# Altium schematic sheet steam-kitv1.SchDoc(steam-kitv1)
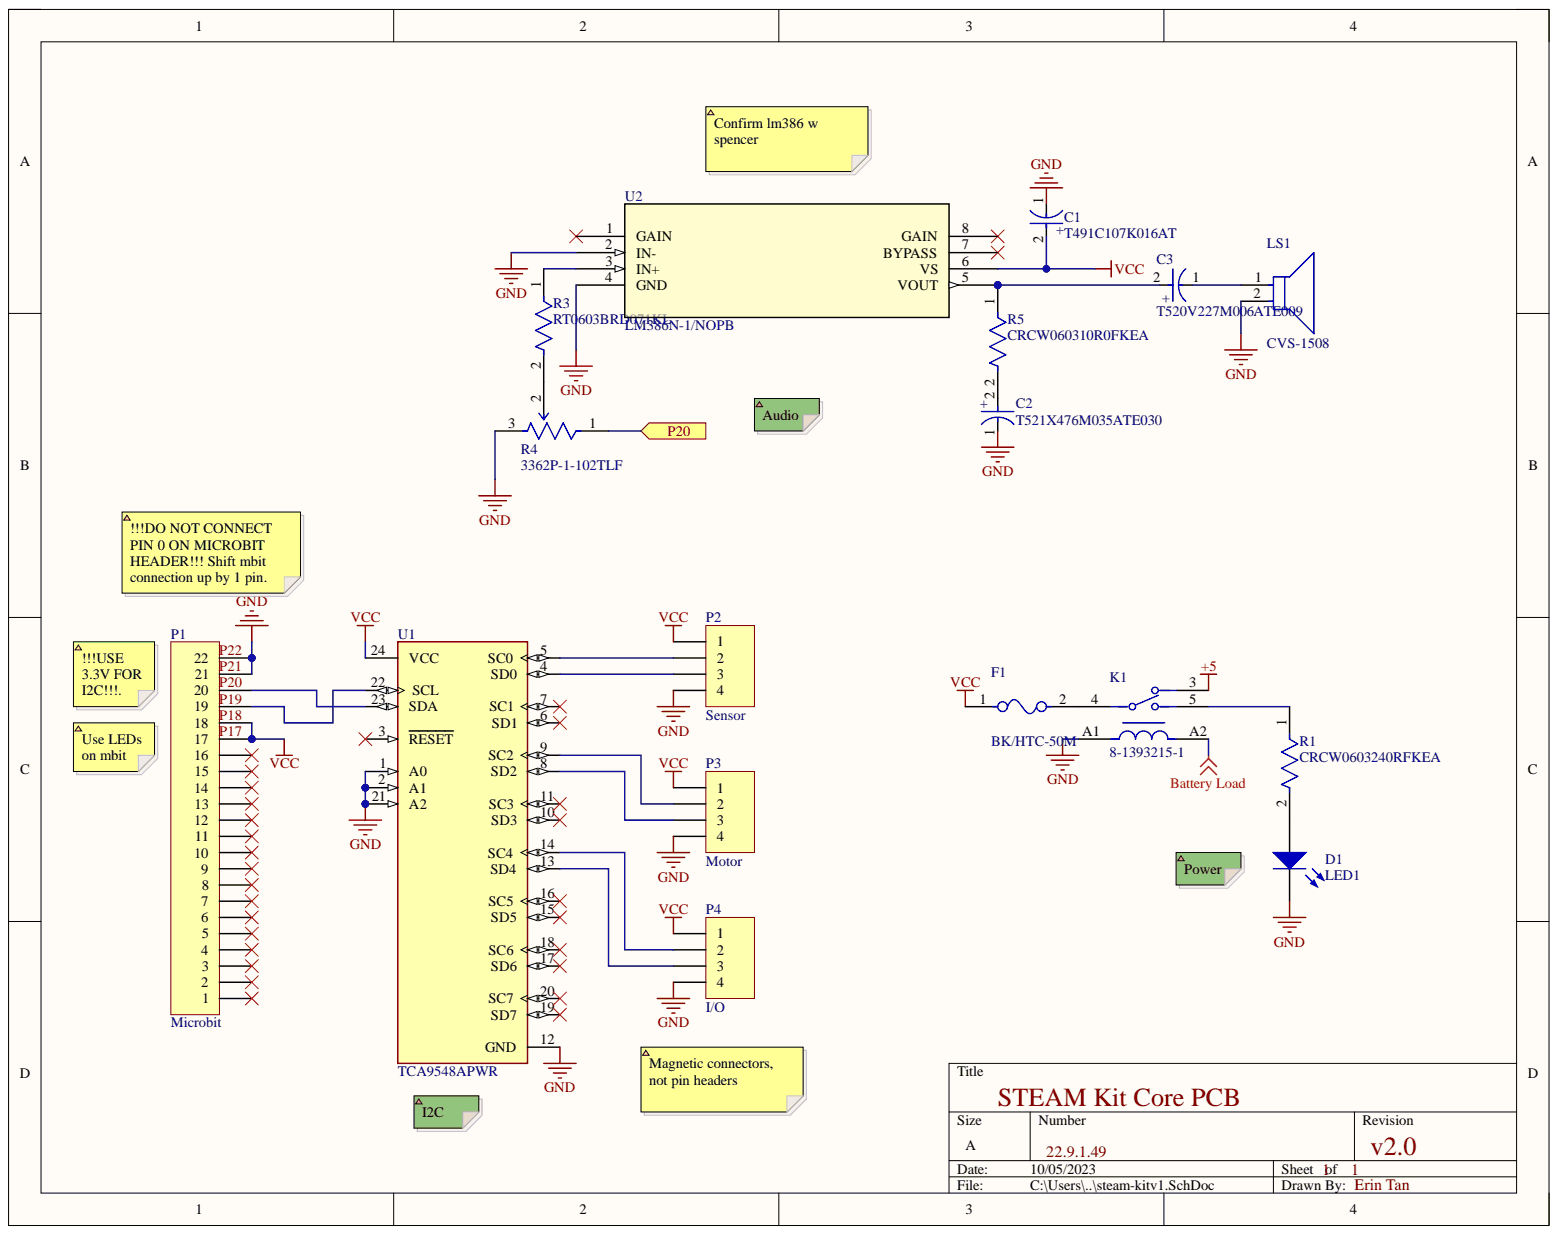
[diagram p0: steam-kitv1.SchDoc]

## Parts
C1  T491C107K016AT  [FP-T491C107K016AT-MFG]
C2  T521X476M035ATE030  [FP-T521X476M035ATE030-MFG]
C3  T520V227M006ATE009  [FP-T520V227M006ATE009-MFG]
D1  LED1  [LED-1]
F1  BK/HTC-50M  [FP-BK-HTC-50M-MFG]
K1  8-1393215-1  [FP-8-1393215-1-MFG]
LS1  CVS-1508  [FP-CVS-1508-MFG]
P1  Microbit  [HDR1X22]
P2  Sensor  [HDR1X4]
P3  Motor  [HDR1X4]
P4  I/O  [HDR1X4]
R1  CRCW0603240RFKEA  [FP-CRCW0603-e3-IPC_B]
R3  RT0603BRD071KL  [FP-RT0603-MFG]
R4  3362P-1-102TLF  [FP-3362P-1-102TLF-MFG]
R5  CRCW060310R0FKEA  [FP-CRCW0603-e3-IPC_A]
U1  TCA9548APWR  [FP-PW0024A-MFG]
U2  LM386N-1/NOPB  [N08E_TEX]

## Ports
P20

## Nets
+5: K1.3
Battery Load: K1.A2
GND: C1.1 C2.1 D1.2 K1.A1 LS1.2 P1.21 P1.22 P2.4 P3.4 P4.4 R4.3 U1.1(A0) U1.2(A1) U1.12(GND) U1.21(A2) U2.2(IN-) U2.4(GND)
VCC: C1.2 F1.1 P1.17 P1.18 P2.1 P3.1 P4.1 U1.24(VCC) U2.6(VS)
P20: R4.1 ; port P20
NetC2_2: C2.2 R5.2
NetC3_1: C3.1 LS1.1
NetC3_2: C3.2 R5.1 U2.5(VOUT)
NetD1_1: D1.1 R1.2
NetF1_2: F1.2 K1.4
NetK1_5: K1.5 R1.1
NetP1_19: P1.19 U1.22(SCL)
NetP1_20: P1.20 U1.23(SDA)
NetP2_2: P2.2 U1.5(SC0)
NetP2_3: P2.3 U1.4(SD0)
NetP3_2: P3.2 U1.9(SC2)
NetP3_3: P3.3 U1.8(SD2)
NetP4_2: P4.2 U1.14(SC4)
NetP4_3: P4.3 U1.13(SD4)
NetR3_1: R3.1 U2.3(IN+)
NetR3_2: R3.2 R4.2
P17:
P18:
P19:
P20:
P21:
P22:

Not connected: P1 1 2 3 4 5 6 7 8 9 10 11 12 13 14 15 16; U1 3(RESET) 6(SD1) 7(SC1) 10(SD3) 11(SC3) 15(SD5) 16(SC5) 17(SD6) 18(SC6) 19(SD7) 20(SC7); U2 1(GAIN) 7(BYPASS) 8(GAIN)

## Library data of the parts
C1 = CMP-03017-000015-1: CAP TANT 100UF 10% 16V 2312; Dielectric Material: Tantalum; ESR (Equivalent Series Resistance): 1R; Leakage Current: 16uA; Life (Hours): 2000Hour; Polarity: Polar; Ripple Current: 133mA; Capacitance: 100uF; Case Code (Imperial): 2413; Case Code (Metric): 6032; Case/Package: Molded; Component Kind: Standard; Construction: Flat; Depth: 3.2mm; Dissipation Factor: 10%; Features: General Purpose; Height: 2.5mm; Height - Seated (Max): 0.11inch; Lead Free: Lead Free; Length: 6mm; Library Name: Altium Content Vault; Max Operating Temperature: 125°C; Min Operating Temperature: -55°C; Mount: Surface Mount; Number of Pins: 2; Package Quantity: 1; Packaging: Tape and Reel; Radiation Hardening: No; REACH SVHC: No SVHC; RoHS Compliant: Yes; Series: T491; Termination: SMD/SMT; Tolerance: 10%; Voltage: 16V; Voltage Rating: 16V; Voltage Rating (DC): 16V; Width: 0.126inch
C2 = CMP-03016-000019-1: CAP TANT POLY 47UF 35V 2917; Dissipation Factor: 10%; ESR (Equivalent Series Resistance): 30mR; Life (Hours): 2000Hour; Polarity: Polar; Tolerance: 20%; Capacitance: 47uF; Case Code (Imperial): 2917; Case Code (Metric): 7343; Case/Package: 2917; Component Kind: Standard; Construction: Flat; Depth: 4.3mm; Features: General Purpose; Height: 4mm; Height - Seated (Max): 0.169inch; Length: 7.3mm; Library Name: Altium Content Vault; Max Operating Temperature: 125°C; Min Operating Temperature: -55°C; Mount: Surface Mount; Number of Pins: 2; Package Quantity: 1; Packaging: Tape and Reel; REACH SVHC: No SVHC; RoHS Compliant: Yes; Series: KO-CAP T521; Termination: SMD/SMT; Voltage Rating: 35V; Voltage Rating (DC): 35V; Width: 4.3mm
C3 = CMP-03017-000074-1: CAP TANT POLY 220UF 6.3V 2917; Dissipation Factor: 10%; ESR (Equivalent Series Resistance): 9mR; Leakage Current: 139uA; Life (Hours): 2000Hour; Polarity: Polar; Resistance: 0.009R; Ripple Current: 3.1A; Tolerance: 20%; Capacitance: 220uF; Case Code (Imperial): 2917; Case Code (Metric): 7343; Case/Package: 2917; Component Kind: Standard; Construction: Flat; Depth: 4.3mm; Features: General Purpose; Height: 1.9mm; Height - Seated (Max): 0.075inch; Lead Free: Lead Free; Length: 7.3mm; Library Name: Altium Content Vault; Max Operating Temperature: 105°C; Min Operating Temperature: -55°C; Mount: Surface Mount; Number of Pins: 2; Package Quantity: 1; Packaging: Tape and Reel; Radiation Hardening: No; Reach SVHC Compliant: Yes; RoHS Compliant: Yes; Series: KO-CAP T520; Termination: SMD/SMT; Voltage Rating: 6.3V; Voltage Rating (DC): 6.3V; Width: 0.169inch
D1 = LED1: Typical RED GaAs LED; Component Kind: Standard; LatestRevisionDate: 08-Jul-2005; LatestRevisionNote: Mech Layer 1 removed.; Library Name: Miscellaneous Devices.IntLib; PackageDescription: LED; 2 Leads; PackageReference: LED-1; Published: 8-Jun-2000; Simulation: LED1; Publisher: Altium Limited
F1 = CMP-31518-000002-1: Fuse Holder 10A 250V 1 Circuit Cartridge Through Hole; Manufacturer: Cooper Bussmann; Conn Orientation: Right Angle; Number Of Fuses: 1; Automotive: No; Category: Fuse; Component Kind: Standard; ComponentLink2Description: Datasheet; ComponentLink2URL: https://media.digikey.com/pdf/Data%20Sheets/Cooper%20Bussmann%20PDFs/HTC_Series_Rev_Dec_2017.pdf; Device Class L1: Circuit Protection; Device Class L2: Fuse Holders; Device Class L3: unset; Digikey Description: FUSE HOLDER CART 250V 6.3A PCB; Fuse Current: 10A; Height: 11.7mm; Lead Free: Yes; Library Name: Altium Content Vault; Manufacturer Part Number: BK/HTC-50M; Mouser Description: Fuse Holder Panel Mount; Octopart: https://octopart.com/bk%2Fhtc-50m-cooper+bussmann-12077396; Package: 0; RoHS: TRUE; Temperature: -30°C to +85°C; Temperature Range High: +85°C; Temperature Range Low: -30°C
K1 = CMP-03395-000097-1: SPDT PCB mount Non-Latching Relay, 24V dc Coil; Manufacturer: TE Connectivity; Coil Power: 480mW; Coil Resistance: 1200Ω; Current Rating: 8A; Number Of Contacts: 1; Ambient Temperature Range High: +70°C; Ambient Temperature Range Low: -40°C; Automotive: No; Category: Relay; Coil Type: Non-Latching; Coil Voltage: 24V; Component Kind: Standard; ComponentLink2Description: Datasheet; ComponentLink2URL: https://www.te.com/usa-en/product-8-1393215-1.html?te_bu=Cor&te_type=other&te_campaign=oct_glo_cor-oct-global-oth-prtnr-fy19-oct-octo-buy-bom_sma-298_2&elqCampaignId=35216; Device Class L1: Electromechanical; Device Class L2: Relays; Device Class L3: Power Relays; Digikey Description: RELAY GEN PURPOSE SPDT 8A 24V; Height: 25.1mm; Lead Free: Yes; Library Name: Altium Content Vault; Manufacturer Part Number: 8-1393215-1; Octopart: https://octopart.com/8-1393215-1-te+connectivity-40939378; Package: 8-1393215-1; RoHS: TRUE; Throw Configuration: SPDT
LS1 = CMP-14846-000001-1: CVS Seies 15 mm round 7.5 mm deep Mylar 300 mW 8 Ohm 1.7 kHz PCB mount Speaker; Manufacturer: CUI Inc; Frequency: 1700Hz; Resistance: 8Ω; Value: 8Ω; Automotive: No; Category: Speak; Component Kind: Standard; ComponentLink2Description: Datasheet; ComponentLink2URL: https://www.cui.com/product/resource/cvs-1508.pdf; Device Class L1: Electromechanical; Device Class L2: Audio Products; Device Class L3: Speakers; Digikey Description: SPEAKER 8OHM 300MW TOP PORT 87DB; Height: 8mm; Lead Free: Yes; Library Name: Altium Content Vault; Manufacturer Part Number: CVS-1508; Mouser Description: Speakers & Transducers Speakers; Octopart: https://octopart.com/cvs-1508-cui-21325683; Package: CVS-1508; RoHS: TRUE; Temperature: -20°C to +55°C; Temperature Range High: +55°C; Temperature Range Low: -20°C
P1 = Header 22: Header, 22-Pin; Component Kind: Standard; LatestRevisionDate: 17-Jul-2002; LatestRevisionNote: Re-released for DXP Platform.; Library Name: Miscellaneous Connectors.IntLib; Signal Integrity: Connector; Publisher: Altium Limited
P2-P4 = Header 4: Header, 4-Pin; Component Kind: Standard; LatestRevisionDate: 17-Jul-2002; LatestRevisionNote: Re-released for DXP Platform.; Library Name: Miscellaneous Connectors.IntLib; Signal Integrity: Connector; Publisher: Altium Limited
R1 = CMP-2000-03111-2: RES Thick Film, 240Ω, 1%, 0.1W, 100ppm/°C, 0603; Max Power Dissipation: 100mW; Resistance: 240R; Voltage Rating: 75V; Case Code (Imperial): 0603; Case Code (Metric): 1608; Case/Package: 0603; Component Kind: Standard; Construction: Rectangular; Contact Plating: Tin; Depth: 0.85mm; Features: Automotive AEC-Q200; Height: 0.45mm; Lead Free: Lead Free; Length: 1.55mm; Library Name: Altium Content Vault; Max Operating Temperature: 155°C; Military Standard: Not; Min Operating Temperature: -55°C; Mount: Surface Mount; Number of Terminations: 2; Package Quantity: 10; Packaging: Tape and Reel; Power Rating: 100mW; Radiation Hardening: No; Resistance Tolerance: 1%; Resistor Type: Thick Film; RoHS Compliant: Yes; Series: CRCW; Size Code: 0603; Technology: THICK FILM; Temperature Coefficient: 100ppm/°C; Termination: SMD/SMT; Tolerance: 1%; Voltage Rating (DC): 75V; Weight: 7.1E-05oz; Width: 0.033inch
R3 = CMP-03412-013082-1: High Precision-High Stability Chip Resistor Thin Film 0603 1kOhm 0.1% Paper T/R; Manufacturer: Yageo; Power Rating: 0.1W; Resistance: 1kΩ; Temperature Coefficient: 25ppm/°C; Value: 1kΩ; Voltage Rating: 75V; Automotive: No; Category: Res; Component Kind: Standard; ComponentLink2Description: Datasheet; ComponentLink2URL: https://www.yageo.com/upload/media/product/productsearch/datasheet/rchip/PYu-RT_1-to-0.01_RoHS_L_11.pdf; Composition: Thin Film; Device Class L1: Passive Components; Device Class L2: Resistors; Device Class L3: Chip SMD Resistors; Digikey Description: RES SMD 1K OHM 0.1% 1/10W 0603; Footprint Url: https://www.yageo.com/upload/website/yageo_PYu-R_Mount_10_19050818_343.pdf; Height: 0.55mm; Lead Free: Yes; Library Name: Altium Content Vault; Manufacturer Part Number: RT0603BRD071KL; Octopart: https://octopart.com/rt0603brd071kl-yageo-1433776; Package: RT0603; RoHS: TRUE; Series: RT; Temperature: -55°C to +155°C; Temperature Range High: +155°C; Temperature Range Low: -55°C; Tolerance: 0.1%
R4 = CMP-07234-000030-1: TRIMMER 1K OHM 0.5W PC PIN TOP; Max Power Dissipation: 500mW; Number of Turns: 1; Resistance: 1kR; Temperature Coefficient: 100ppm/°C; Tolerance: 10%; Component Kind: Standard; Contact Plating: Tin; Depth: 7.04mm; Height: 0.185inch; Length: 6.71mm; Library Name: Altium Content Vault; Max Operating Temperature: 125°C; Military Standard: Not; Min Operating Temperature: -55°C; Mount: Through Hole; Package Quantity: 200; Packaging: Rail/Tube; Power Rating: 500mW; Resistor Type: Cermet; RoHS Compliant: Yes; Series: Trimpot® 3362 - Sealed; Technology: CERMET; Termination: Pin; Weight: 0.02oz; Width: 4.7mm
R5 = CMP-2000-03845-2: RES Thick Film, 10Ω, 1%, 0.1W, 100ppm/°C, 0603; Max Power Dissipation: 100mW; Resistance: 10R; Voltage Rating: 75V; Case Code (Imperial): 0603; Case Code (Metric): 1608; Case/Package: 0603; Component Kind: Standard; Composition: Thick Film; Contact Plating: Tin; Depth: 0.85mm; Features: Automotive AEC-Q200; Height: 0.45mm; Lead Free: Lead Free; Length: 1.55mm; Library Name: Altium Content Vault; Max Operating Temperature: 155°C; Military Standard: Not; Min Operating Temperature: -55°C; Mount: Surface Mount; Number of Pins: 2; Number of Terminations: 2; Package Quantity: 10; Packaging: Tape and Reel; Power Rating: 100mW; Temperature Coefficient: 100ppm/°C; Termination: SMD/SMT; Tolerance: 1%; Voltage Rating (DC): 75V; Weight: 7.1E-05oz; Width: 0.033inch
U1 = CMP-04892-000002-1: Bus Switch 1 x 8:1 24-TSSOP; Manufacturer: Texas Instruments; Interface: I2C,SMBus; Max Supply Voltage: 5.5V; Min Supply Voltage: 1.65V; Mux Demux Ratio: 1:8; Nominal Supply Current: 2-50uA; Number Of Circuits: 1; Standoff Height: 0.05mm; Ambient Temperature Range High: +85°C; Ambient Temperature Range Low: -40°C; Automotive: No; Category: IC; Component Kind: Standard; ComponentLink2Description: Datasheet; ComponentLink2URL: http://www.ti.com/lit/ds/symlink/tca9548a.pdf; Device Class L1: Integrated Circuits (ICs); Device Class L2: Interface ICs; Device Class L3: Multiplexers; Digikey Description: IC I2C SW 8CH W/RESET 24TSSOP; Height: 1.2mm; Ipc Land Pattern Name: SOP65P640X120-24; Lead Free: Yes; Library Name: Altium Content Vault; Manufacturer Part Number: TCA9548APWR; Mouser Description: Low-Voltage 8-Channel I2C Switch With Reset 24-TSSOP -40 to 85; Octopart: https://octopart.com/tca9548apwr-texas+instruments-22266789; Package: PW0024A; RoHS: TRUE; Temperature: -40°C to +85°C; Temperature Range High: +85°C; Temperature Range Low: -40°C
U2 = LM386N-1NOPB: No Description Available; Mfr_Name: Texas Instruments; Component Kind: Standard; Library Name: LM386N-1NOPB.SchLib; Manufacturer_Part_Number: LM386N-1/NOPB; RefDes: RefDes; Type: DEV; Copyright: Copyright (C) 2023 Ultra Librarian. All rights reserved.
